# Altium schematic sheet ICOM-IC-9700-Pan.SchDoc
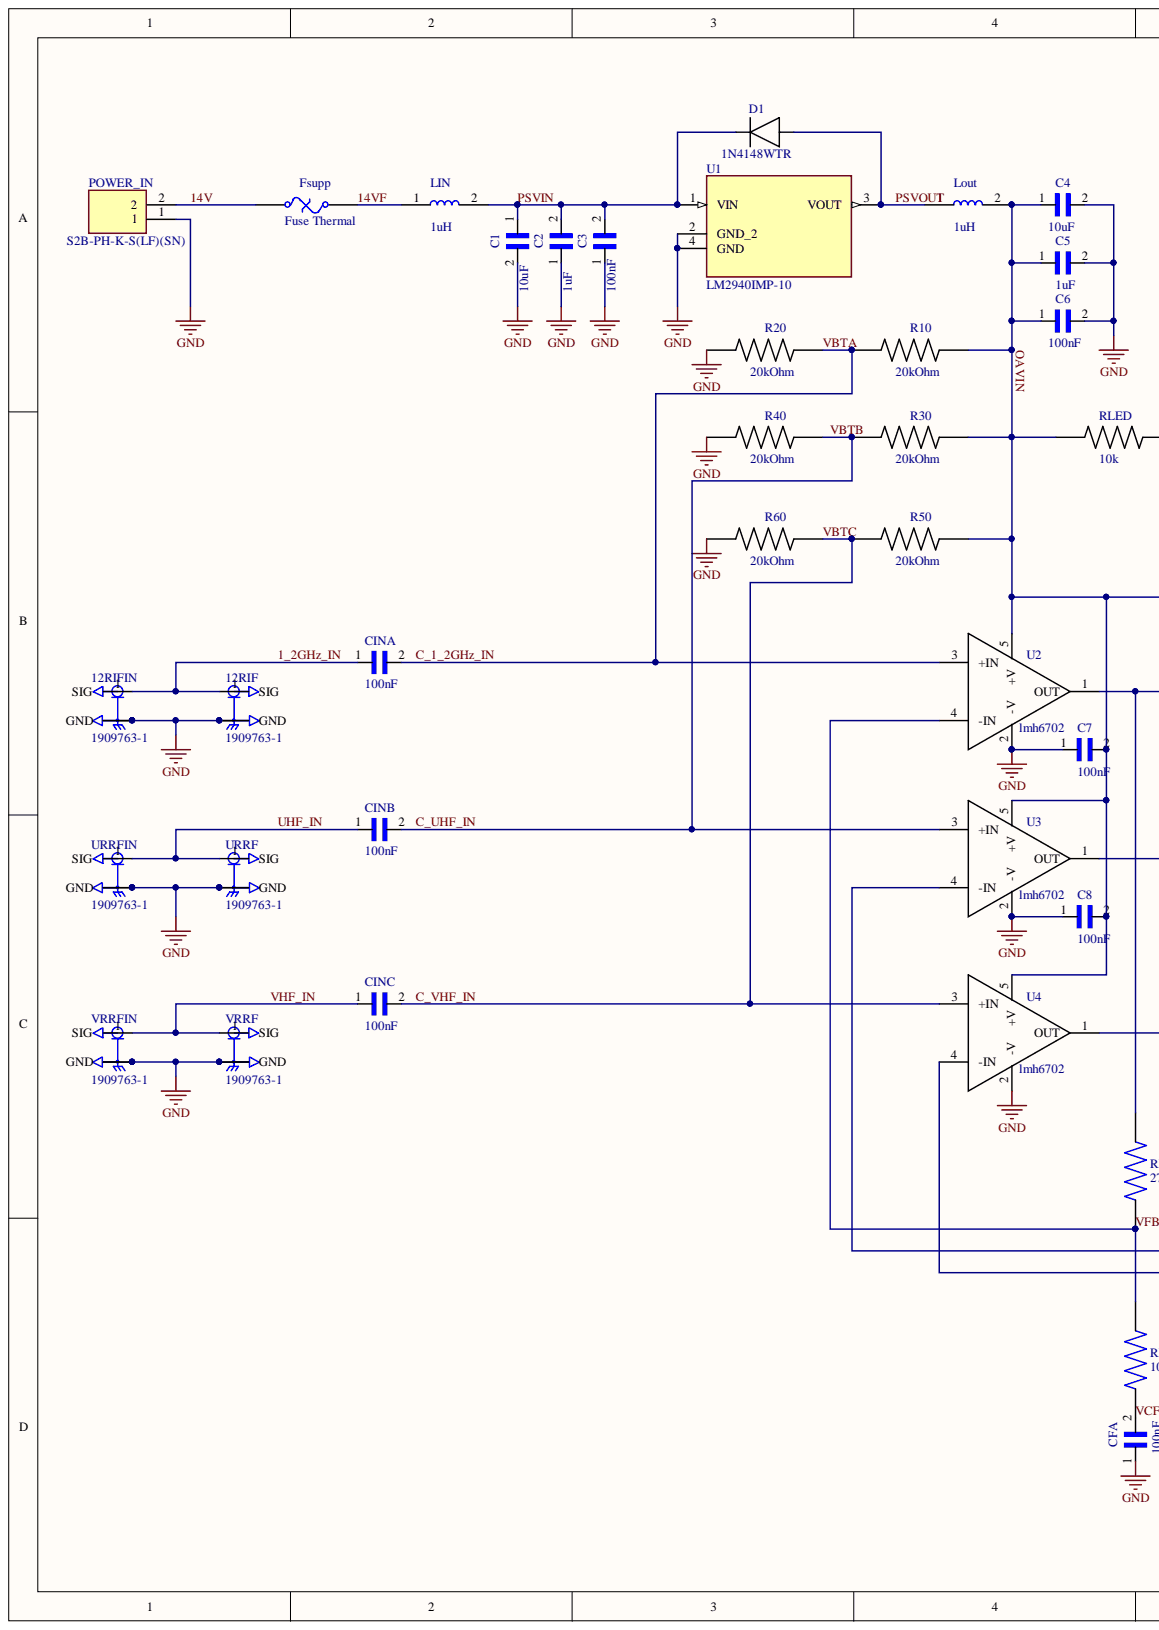
[diagram p0: ICOM-IC-9700-Pan.SchDoc, left]
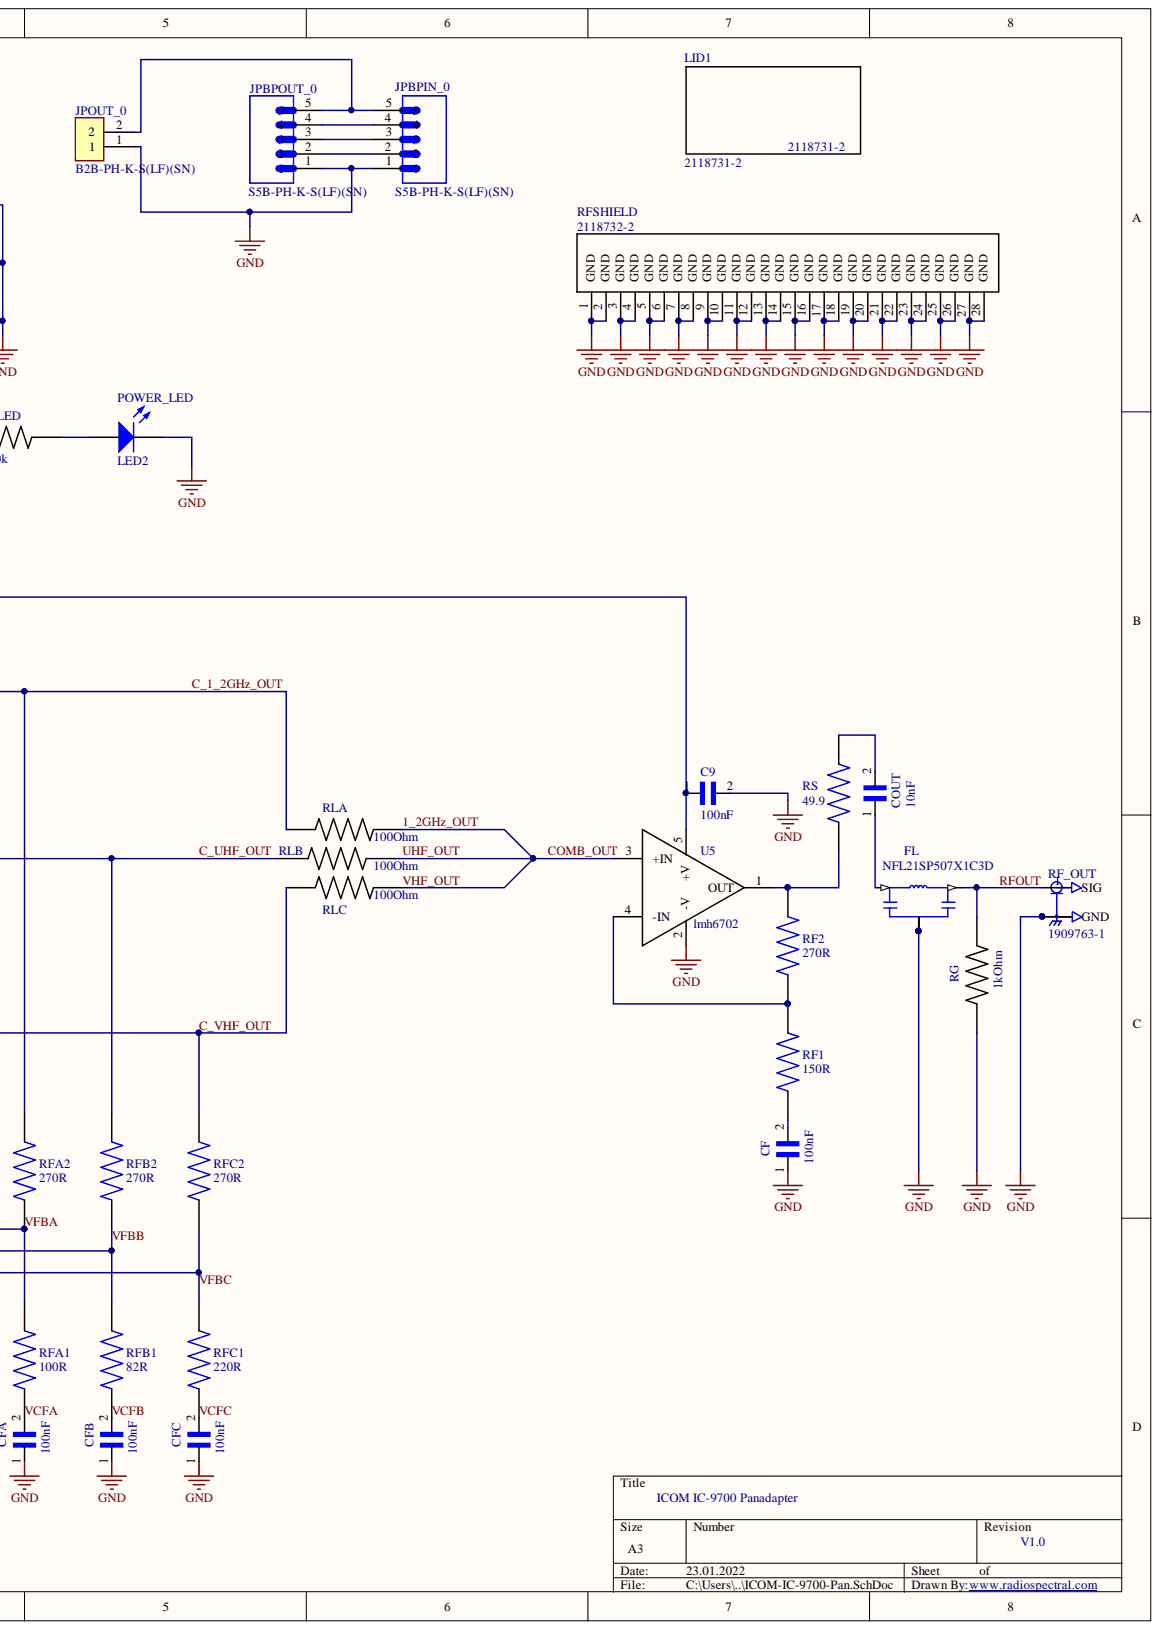
[diagram p1: ICOM-IC-9700-Pan.SchDoc, right]

## Parts
12RIF  1909763-1  [1909763-1]
12RIFIN  1909763-1  [1909763-1]
C1  10uF  [CAP0805]
C2  1uF  [CAP0805]
C3  100nF  [CAP0805]
C4  10uF  [CAP0805]
C5  1uF  [CAP0805]
C6  100nF  [CAP0805]
C7  100nF  [CAP0805]
C8  100nF  [CAP0805]
C9  100nF  [CAP0805]
CF  100nF  [CAP0805]
CFA  100nF  [CAP0805]
CFB  100nF  [CAP0805]
CFC  100nF  [CAP0805]
CINA  100nF  [CAP-0603]
CINB  100nF  [CAP-0603]
CINC  100nF  [CAP-0603]
COUT  10nF  [CAP0805]
D1  1N4148WTR  [SOD123]
FL  NFL21SP507X1C3D  [FIL_NFL21SP507X1C3D]
Fsupp  Fuse Thermal  [PTTC0603]
JPBPIN_0  S5B-PH-K-S(LF)(SN)  [JST_S5B-PH-K-S(LF)(SN)]
JPBPOUT_0  S5B-PH-K-S(LF)(SN)  [JST_S5B-PH-K-S(LF)(SN)]
JPOUT_0  B2B-PH-K-S(LF)(SN)  [JST_B2B-PH-K-S(LF)(SN)]
LID1  2118731-2
LIN  1uH  [IND0805]
Lout  1uH  [IND0805]
POWER_IN  S2B-PH-K-S(LF)(SN)  [JST_S2B-PH-K-S(LF)(SN)]
POWER_LED  LED2  [LED0805]
R10  20kOhm  [RES-0603]
R20  20kOhm  [RES-0603]
R30  20kOhm  [RES-0603]
R40  20kOhm  [RES-0603]
R50  20kOhm  [RES-0603]
R60  20kOhm  [RES-0603]
RF1  150R  [RES0805]
RF2  270R  [RES0805]
RF_OUT  1909763-1  [1909763-1]
RFA1  100R  [RES0805]
RFA2  270R  [RES0805]
RFB1  82R  [RES0805]
RFB2  270R  [RES0805]
RFC1  220R  [RES0805]
RFC2  270R  [RES0805]
RFSHIELD  2118732-2  [2118732-2]
RG  1kOhm  [RES0805]
RLA  100Ohm  [RES0805]
RLB  100Ohm  [RES0805]
RLC  100Ohm  [RES0805]
RLED  10k  [RES0805]
RS  49.9  [RES0805]
U1  LM2940IMP-10  [SOT230P696X180-4N]
U2  lmh6702  [SOT95P265X145-5N]
U3  lmh6702  [SOT95P265X145-5N]
U4  lmh6702  [SOT95P265X145-5N]
U5  lmh6702  [SOT95P265X145-5N]
URRF  1909763-1  [1909763-1]
URRFIN  1909763-1  [1909763-1]
VRRF  1909763-1  [1909763-1]
VRRFIN  1909763-1  [1909763-1]

## Nets
GND: 12RIF.2 12RIF.3 12RIFIN.2 12RIFIN.3 C1.2 C2.1 C3.1 C4.2 C5.2 C6.2 C7.1 C8.1 C9.2 CF.1 CFA.1 CFB.1 CFC.1 FL.2 FL.2_1 FL.2_2 FL.2_3 FL.2_4 JPBPIN_0.1 JPBPOUT_0.1 JPOUT_0.1 POWER_IN.1 POWER_LED.2 R20.1 R40.1 R60.1 RF_OUT.2 RF_OUT.3 RFSHIELD.1(GND) RFSHIELD.2(GND) RFSHIELD.3(GND) RFSHIELD.4(GND) RFSHIELD.5(GND) RFSHIELD.6(GND) RFSHIELD.7(GND) RFSHIELD.8(GND) RFSHIELD.9(GND) RFSHIELD.10(GND) RFSHIELD.11(GND) RFSHIELD.12(GND) RFSHIELD.13(GND) RFSHIELD.14(GND) RFSHIELD.15(GND) RFSHIELD.16(GND) RFSHIELD.17(GND) RFSHIELD.18(GND) RFSHIELD.19(GND) RFSHIELD.20(GND) RFSHIELD.21(GND) RFSHIELD.22(GND) RFSHIELD.23(GND) RFSHIELD.24(GND) RFSHIELD.25(GND) RFSHIELD.26(GND) RFSHIELD.27(GND) RFSHIELD.28(GND) RG.1 U1.2(GND_2) U1.4(GND) U2.2(-V) U3.2(-V) U4.2(-V) U5.2(-V) URRF.2 URRF.3 URRFIN.2 URRFIN.3 VRRF.2 VRRF.3 VRRFIN.2 VRRFIN.3
1_2GHz_IN: 12RIF.1 12RIFIN.1 CINA.1
1_2GHz_OUT: RLA.2 RLB.2 RLC.2 U5.3(+IN)
14V: Fsupp.1 POWER_IN.2
14VF: Fsupp.2 LIN.1
C_1_2GHz_IN: CINA.2 R10.1 R20.2 U2.3(+IN)
C_1_2GHz_OUT: RFA2.2 RLA.1 U2.1(OUT)
C_UHF_IN: CINB.2 R30.1 R40.2 U3.3(+IN)
C_UHF_OUT: RFB2.2 RLB.1 U3.1(OUT)
C_VHF_IN: CINC.2 R50.1 R60.2 U4.3(+IN)
C_VHF_OUT: RFC2.2 RLC.1 U4.1(OUT)
OAVIN: C4.1 C5.1 C6.1 C7.2 C8.2 C9.1 Lout.2 R10.2 R30.2 R50.2 RLED.1 U2.5(+V) U3.5(+V) U4.5(+V) U5.5(+V)
PSVIN: C1.1 C2.2 C3.2 D1.C LIN.2 U1.1(VIN)
PSVOUT: D1.A Lout.1 U1.3(VOUT)
RFOUT: FL.3 RF_OUT.1 RG.2
UHF_IN: CINB.1 URRF.1 URRFIN.1
VCFA: CFA.2 RFA1.1
VCFB: CFB.2 RFB1.1
VCFC: CFC.2 RFC1.1
VFBA: RFA1.2 RFA2.1 U2.4(-IN)
VFBB: RFB1.2 RFB2.1 U3.4(-IN)
VFBC: RFC1.2 RFC2.1 U4.4(-IN)
VHF_IN: CINC.1 VRRF.1 VRRFIN.1
NetCF_2: CF.2 RF1.1
NetCOUT_1: COUT.1 FL.1
NetCOUT_2: COUT.2 RS.2
NetJPBPIN_0_2: JPBPIN_0.2 JPBPOUT_0.2
NetJPBPIN_0_3: JPBPIN_0.3 JPBPOUT_0.3
NetJPBPIN_0_4: JPBPIN_0.4 JPBPOUT_0.4
NetJPBPIN_0_5: JPBPIN_0.5 JPBPOUT_0.5 JPOUT_0.2
NetPOWER_LED_1: POWER_LED.1 RLED.2
NetRF1_2: RF1.2 RF2.1 U5.4(-IN)
NetRF2_2: RF2.2 RS.1 U5.1(OUT)

## Library data of the parts
12RIF 12RIFIN URRF URRFIN VRRF VRRFIN = 1909763-1: Conn Coaxial PL 50Ohm Solder ST SMD Gold Over Nickel T/R; MF: TE Connectivity; Number_of_Positions: 1; Value: UF.L; Component Kind: Standard; Digi-Key_Part_Number: A118077DKR-ND; Digi-Key_Purchase_URL: https://www.digikey.com/product-detail/en/te-connectivity-amp-connectors/1909763-1/A118077DKR-ND/4914093; Library Name: 1909763-1.SchLib; MP: 1909763-1; Package: None; Price: None; TE_purchase_URL: https://www.te.com/usa-en/product-1909763-1.html?te_bu=Cor&te_type=disp&te_campaign=seda_glo_cor-seda-global-disp-prtnr-fy19-seda-model-bom-cta_sma-317_1&elqCampaignId=32493
C1 C4 = CL21A106KAYNNNE: Cap Ceramic 10uF 25V X5R 10% SMD 0805 85Â°C Embossed T/R; MF: Samsung Electro-Mechanics; Component Kind: Standard; Digi-Key_Part_Number: 1276-2891-6-ND; Digi-Key_Purchase_URL: https://www.digikey.com.tr/product-detail/en/samsung-electro-mechanics/CL21A106KAYNNNE/1276-2891-1-ND/3890977?utm_source=snapeda&utm_medium=aggregator&utm_campaign=symbol; Library Name: CL21A106KAYNNNE.IntLib; MP: CL21A106KAYNNNE; Package: 0805 Samsung; SnapEDA_Link: https://www.snapeda.com/parts/CL21A106KAYNNNE/Samsung%20Electro-Mechanics/view-part/?ref=snap; Value: 10uF
C2 C5 = CGA4J2X8R1H104K125AA: Eia Case Size: 0805, Voltage: 50, Cap Value: 100000, Tol: +/-10%, Thickness: 1.25 Â± 0.20; MF: KEMET; MP: C0805C105Z4VACTU; Value: 1uF 16V; Component Kind: Standard; Digi-Key_Part_Number: 399-8011-6-ND; Digi-Key_Purchase_URL: https://www.digikey.com/product-detail/en/kemet/C0805C105Z4VACTU/399-8011-6-ND/3472542; Library Name: CGA4J2X8R1H104K125AA.IntLib; Package: 0805
C3 C7 C8 = CL21C102JBCNNNC: CAP CER 1000PF 50V C0G/NP0 0805; MF: TDK Corporation; MP: CGA4J2X8R1H104K125AA; Value: 100nF 50V; Component Kind: Standard; Digi-Key_Part_Number: 445-5909-6-ND; Digi-Key_Purchase_URL: https://www.digikey.com/product-detail/en/tdk-corporation/CGA4J2X8R1H104K125AA/445-5909-6-ND/2444516\; Library Name: CL21C102JBCNNNC.IntLib; Package: 0805
C6 C9 = CL21C102JBCNNNC: CAP CER 100nF 50V C0G/NP0 0805; MF: TDK Corporation; MP: CGA4J2X8R1H104K125AA; Component Kind: Standard; Digi-Key_Part_Number: 445-5909-6-ND; Digi-Key_Purchase_URL: https://www.digikey.com/product-detail/en/tdk-corporation/CGA4J2X8R1H104K125AA/445-5909-6-ND/2444516\; Library Name: CL21C102JBCNNNC.IntLib; Package: 0805; Value: 100nF 50V
CF CFA CFB CFC = CGA4J2X8R1H104K125AA: Eia Case Size: 0805, Voltage: 50, Cap Value: 100000, Tol: +/-10%, Thickness: 1.25 Â± 0.20; MF: TDK Corporation; Value: 100nF 50V; Component Kind: Standard; Digi-Key_Part_Number: 445-5909-6-ND; Digi-Key_Purchase_URL: https://www.digikey.com/product-detail/en/tdk-corporation/CGA4J2X8R1H104K125AA/445-5909-6-ND/2444516\; Library Name: CGA4J2X8R1H104K125AA.IntLib; MP: CGA4J2X8R1H104K125AA; Package: 0805
CINA CINB CINC = CGA4J2X8R1H104K125AA: Eia Case Size: 0805, Voltage: 50, Cap Value: 100000, Tol: +/-10%, Thickness: 1.25 Â± 0.20; MF: TDK; MP: CL10B104KA8NNNC; Component Kind: Standard; Digi-Key_Part_Number: 1276-1006-1-ND; Digi-Key_Purchase_URL: https://www.digikey.com/product-detail/en/samsung-electro-mechanics/CL10B104KA8NNNC/1276-1006-1-ND/3889092; Library Name: CGA4J2X8R1H104K125AA.IntLib; Package: 0603; Value: 100nF
COUT = CGA4J2X8R1H104K125AA: Eia Case Size: 0805, Voltage: 50, Cap Value: 100000, Tol: +/-10%, Thickness: 1.25 Â± 0.20; MF: Samsung Electro-Mechanics; MP: CL21C103JBF1PNE; Component Kind: Standard; Digi-Key_Part_Number: 1276-6790-6-ND; Digi-Key_Purchase_URL: https://www.digikey.com/product-detail/en/samsung-electro-mechanics/CL21C103JBF1PNE/1276-6790-6-ND/5962024; Library Name: CGA4J2X8R1H104K125AA.IntLib; Package: 0805; Value: 10nF
D1 = 1N4148WTR: Diode Standard 75V 250mA (DC) Surface Mount SOD-123; Component Kind: Standard; Digi-Key_Part_Number: 1655-1360-6-ND; Digi-Key_Purchase_URL: https://www.digikey.com/product-detail/en/smc-diode-solutions/1N4148WTR/1655-1360-6-ND/6023160; Library Name: 1N4148WTR.SchLib; Value: 1N4148WTR
FL = NFL21SP507X1C3D: LC (Pi) EMI Filter 3rd Order Low Pass 1 Channel L = 31nH, C = 12pF 300mA 0805 (2012 Metric), 3 PC Pad; MF: Murata; Value: EMIF-500MHz; Component Kind: Standard; Digi-Key_Part_Number: 490-6960-1-ND; Digi-Key_Purchase_URL: https://www.digikey.com/product-detail/en/murata-electronics/NFL21SP507X1C3D/490-6960-6-ND/3847173; Library Name: NFL21SP507X1C3D.SchLib; MP: NFL21SP507X1C3D; Package: 0805 Murata
Fsupp = Fuse Thermal: Thermal Fuse 200mA; Component Kind: Standard; Digi-Key_Part_Number: 507-1821-1-ND; Digi-Key_Purchase_URL: https://www.digikey.com/product-detail/en/bel-fuse-inc/0ZCM0020FF2G/507-1821-1-ND/4156270; LatestRevisionDate: 17-Jul-2002; LatestRevisionNote: Re-released for DXP Platform.; Library Name: Miscellaneous Devices.IntLib; PackageDescription: Thru-Hole; 2 Leads; PackageReference: PIN-W2/E2.8; Published: 24-Mar-1999; Publisher: Altium Limited
JPBPIN_0 = S5B-PH-K-S(LF)(SN): Connector Header Through Hole, Right Angle 5 position 0.079 _2.00mm_; MF: JST Sales; MP: B5B-PH-K-S(LF)(SN); Value: 5 Pin; Component Kind: Standard; Digi-Key_Part_Number: 455-1707-ND; Digi-Key_Purchase_URL: https://www.digikey.com/product-detail/en/jst-sales-america-inc/B5B-PH-K-S-LF-SN/455-1707-ND/926614; Library Name: S5B-PH-K-S_LF__SN_.IntLib; Package: None; Price: None
JPBPOUT_0 = S5B-PH-K-S(LF)(SN): Connector Header Through Hole, Right Angle 5 position 0.079 _2.00mm_; MF: JST Sales; MP: PHR-5; Value: 5 Pin; Component Kind: Standard; Digi-Key_Part_Number: 455-1163-ND; Digi-Key_Purchase_URL: https://www.digikey.com/en/products/detail/jst-sales-america-inc/PHR-5/608605; Library Name: S5B-PH-K-S_LF__SN_.IntLib; Package: None; Price: None
JPOUT_0 = B2B-PH-K-S(LF)(SN): Connector Header Through Hole 2 position 0.079 _2.00mm_; MF: JST Sales; Value: 2 pin; Component Kind: Standard; Digi-Key_Part_Number: 455-1704-ND; Digi-Key_Purchase_URL: https://www.digikey.com/short/z2v159; Library Name: B2B-PH-K-S_LF__SN_.SchLib; MP: B2B-PH-K-S(LF)(SN); Package: None; Price: None
LID1 = 2118731-2: Lid for rf-cage.; Component Kind: Standard; Digi-Key_Part_Number: A126136-ND; Digi-Key_Purchase_URL: https://www.digikey.com/product-detail/en/te-connectivity-amp-connectors/2118731-2/A126136-ND/7381875; Library Name: 2118731-2.SchLib
LIN Lout = MLZ2012N1R0LT000: 1ÂµH Shielded Multilayer Inductor 1.15A 60mOhm 0805 _2012 Metric_; MF: TDK; Availability: Unavailable; Component Kind: Standard; Digi-Key_Part_Number: 445-6756-6-ND; Digi-Key_Purchase_URL: https://www.digikey.com/product-detail/en/tdk-corporation/MLZ2012N1R0LT000/445-6756-6-ND/2523662; Library Name: MLZ2012N1R0LT000.SchLib; MP: MLZ2012N1R0LT000; Package: 0805 TDK; Price: None; SnapEDA_Link: https://www.snapeda.com/parts/MLZ2012N1R0LT000/TDK-Lambda%20Americas%20Inc/view-part/1501825/?ref=snap; Value: 1uH
POWER_IN = S2B-PH-K-S(LF)(SN): Connector Header Through Hole, Right Angle 2 position; MF: JST Sales America Inc.; MP: S2B-PH-K-S_LF__SN_; Component Kind: Standard; Digi-Key_Part_Number: 455-1719-ND; Digi-Key_Purchase_URL: https://www.digikey.com/product-detail/en/jst-sales-america-inc/S2B-PH-K-S_LF__SN_/455-1719-ND/926626?utm_source=snapeda&utm_medium=aggregator&utm_campaign=symbol; Library Name: S2B-PH-K-S_LF__SN_.SchLib; Package: Custom Package JST Sales America Inc.; SnapEDA_Link: https://www.snapeda.com/parts/S2B-PH-K-S%28LF%29%28SN%29/JST%20Sales%20America%20Inc./view-part/46878/?ref=snap
POWER_LED = LED2: LED GREEN DIFFUSED 0805 SMD; Value: Green LED; Component Kind: Standard; Digi-Key_Part_Number: 475-1410-6-ND; Digi-Key_Purchase_URL: https://www.digikey.com/products/en?keywords=475-1410-6-ND; LatestRevisionDate: 17-Jul-2002; LatestRevisionNote: Re-released for DXP Platform.; Library Name: Miscellaneous Devices.IntLib; PackageDescription: SM LED; 2 Flat Leads; PackageReference: 3.2X1.6X1.1; PackageVersion: Feb-2002; Published: 8-Jun-2000; Simulation: LED2; Publisher: Altium Limited
R10 R20 R30 R40 R50 R60 = RC0805FR-0720KL: Res Thick Film 0805 20K Ohm 1% 0.125W_1/8W_ ?100ppm/?C Molded SMD Paper T/R; MF: Yageo; MP: RT0603FRE0720KL; Component Kind: Standard; Digi-Key_Part_Number: YAG5727DKR-ND; Digi-Key_Purchase_URL: https://www.digikey.com/en/products/detail/yageo/RT0603FRE0720KL/1075194; Library Name: RC0805FR-0720KL.IntLib; Package: 0603; Value: 20k
RF1 = RC0805FR-07383RL: 150 Ohms Â±1% 0.125W, 1/8W Chip Resistor 0805 (2012 Metric) Moisture Resistant Thick Film; MF: Yageo; MP: RC0805FR-07150RL; Component Kind: Standard; Digi-Key_Part_Number: 311-150CRDKR-ND; Digi-Key_Purchase_URL: https://www.digikey.com/product-detail/en/yageo/RC0805FR-07150RL/311-150CRDKR-ND/733507; Library Name: RC0805FR-07383RL.IntLib; Package: 0805; Value: 150R
RF2 RFA2 RFB2 RFC2 = RC0805FR-07383RL: 383 Ohms Â±1% 0.125W, 1/8W Chip Resistor 0805 (2012 Metric) Moisture Resistant Thick Film; MF: TE Connectivity Passive Product; MP: CRGCQ0805F270R; Component Kind: Standard; Digi-Key_Part_Number: A129742DKR-ND; Digi-Key_Purchase_URL: https://www.digikey.com/product-detail/en/te-connectivity-passive-product/CRGCQ0805F270R/A129742DKR-ND/8578804; Library Name: RC0805FR-07383RL.IntLib; Package: 2012 Rohm Semiconductor; Value: 270R
RF_OUT = 1909763-1: Conn Coaxial PL 50Ohm Solder ST SMD Gold Over Nickel T/R; MF: TE Connectivity; Value: UF.L; Component Kind: Standard; Digi-Key_Part_Number: A118077DKR-ND; Digi-Key_Purchase_URL: https://www.digikey.com/product-detail/en/te-connectivity-amp-connectors/1909763-1/A118077DKR-ND/4914093; Library Name: 1909763-1.SchLib; MP: 1909763-1; TE_purchase_URL: https://www.te.com/usa-en/product-1909763-1.html?te_bu=Cor&te_type=disp&te_campaign=seda_glo_cor-seda-global-disp-prtnr-fy19-seda-model-bom-cta_sma-317_1&elqCampaignId=32493
RFA1 = RC0805FR-07383RL: 100 Ohms Â±1% 0.125W, 1/8W Chip Resistor 0805 (2012 Metric) Moisture Resistant Thick Film; MF: Yageo; MP: RC0805FR-07100RL; Component Kind: Standard; Digi-Key_Part_Number: 311-100CRDKR-ND; Digi-Key_Purchase_URL: https://www.digikey.com/product-detail/en/yageo/RC0805FR-07100RL/311-100CRDKR-ND/733437; Library Name: RC0805FR-07383RL.IntLib; Package: 0805; Value: 100R
RFB1 = RC0805FR-07383RL: 82 Ohms Â±1% 0.125W, 1/8W Chip Resistor 0805 (2012 Metric) Moisture Resistant Thick Film; MF: Yageo; MP: RC0805FR-0782RL; Component Kind: Standard; Digi-Key_Part_Number: 311-82.0CRDKR-ND; Digi-Key_Purchase_URL: https://www.digikey.com/product-detail/en/yageo/RC0805FR-0782RL/311-82-0CRDKR-ND/734058; Library Name: RC0805FR-07383RL.IntLib; Package: 0805; Value: 82R
RFC1 = RC0805FR-07383RL: 220 Ohms Â±1% 0.125W, 1/8W Chip Resistor 0805 (2012 Metric) Moisture Resistant Thick Film; MF: TE Connectivity Passive Product; MP: CRGCQ0805F150R; Component Kind: Standard; Digi-Key_Part_Number: A129741DKR-ND; Digi-Key_Purchase_URL: https://www.digikey.com/short/znc2q9; Library Name: RC0805FR-07383RL.IntLib; Package: 0805; Value: 220R
RFSHIELD = 2118732-2: Rf cage.; Component Kind: Standard; Digi-Key_Part_Number: A126160TR-ND; Digi-Key_Purchase_URL: https://www.digikey.com/product-detail/en/te-connectivity-amp-connectors/2118732-2/A126160CT-ND/7381920; Library Name: 2118732-2.SchLib
RG = RC0805FR-071KL: 0805/Case Surface Mount/Pkg 1/10-Watt 1k-Ohms 1pct-Tol Thick Film Chip Resistor; MF: Yageo; Value: 1k; Component Kind: Standard; Digi-Key_Part_Number: 311-1.00KCRDKR-ND; Digi-Key_Purchase_URL: https://www.digikey.com.tr/product-detail/en/yageo/RC0805FR-071KL/311-1.00KCRCT-ND/730391?utm_source=snapeda&utm_medium=aggregator&utm_campaign=symbol; Library Name: RC0805FR-071KL.IntLib; MP: RC0805FR-071KL; Package: 2012 Rohm Semiconductor; SnapEDA_Link: https://www.snapeda.com/parts/RC0805FR-071KL/Yageo/view-part/?ref=snap
RLA RLB RLC = RC0805FR-071KL: 0805/Case Surface Mount/Pkg 1/10-Watt 1k-Ohms 1pct-Tol Thick Film Chip Resistor; MF: Yaego; MP: RC0805FR-07100RL; Value: 100R; Component Kind: Standard; Digi-Key_Part_Number: 311-100CRDKR-ND; Digi-Key_Purchase_URL: https://www.digikey.com/short/znc2b8; Library Name: RC0805FR-071KL.IntLib; Package: 0805
RLED = RC0805FR-072K2L: 2.2 kOhms Â±1% 0.125W, 1/8W Chip Resistor 0805 _2012 Metric_ Moisture Resistant Thick Film; MF: TE connectivity; Component Kind: Standard; Digi-Key_Part_Number: 311-10.0KCRDKR-ND; Digi-Key_Purchase_URL: https://www.digikey.com/short/znc28v; Library Name: RC0805FR-072K2L.IntLib; MP: RC0805FR-072K2L; Package: 0805; SnapEDA_Link: https://www.snapeda.com/parts/RC0805FR-072K2L/Yageo/view-part/615149/?ref=snap; Value: 10k
RS = RC0805FR-07383RL: 383 Ohms Â±1% 0.125W, 1/8W Chip Resistor 0805 (2012 Metric) Moisture Resistant Thick Film; MF: Yageo; MP: RC0805FR-0749R9L; Value: 49R9; Component Kind: Standard; Digi-Key_Part_Number: 311-49.9CRDKR-ND; Digi-Key_Purchase_URL: https://www.digikey.com/product-detail/en/yageo/RC0805FR-0749R9L/311-49-9CRDKR-ND/733878; Library Name: RC0805FR-07383RL.IntLib; Package: 0805
U1 = LM2940IMP-5.0: Linear Voltage Regulator IC 1 Output 1A SOT-223-4; MF: Texas; MP: LM2940IMP-10/NOPB; Value: LM7810; Component Kind: Standard; Digi-Key_Part_Number: LM2940IMP-10/NOPBDKR-ND; Digi-Key_Purchase_URL: https://www.digikey.com/product-detail/en/texas-instruments/LM2940IMP-10-NOPB/LM2940IMP-10-NOPBDKR-ND/1847195; Library Name: LM2940IMP-5.0.SchLib; Package: SOT-223-4; Price: None
U2-U5 = lmh6702: Component Kind: Standard; Digi-Key_Part_Number: LMH6702MF/NOPBDKR-ND; Digi-Key_Purchase_URL: https://www.digikey.com/product-detail/en/texas-instruments/LMH6702MF-NOPB/LMH6702MF-NOPBDKR-ND/1847728; Library Name: lmh6702.SchLib; Value: LMH6702
